# Altium schematic sheet Transcurrent.SchDoc
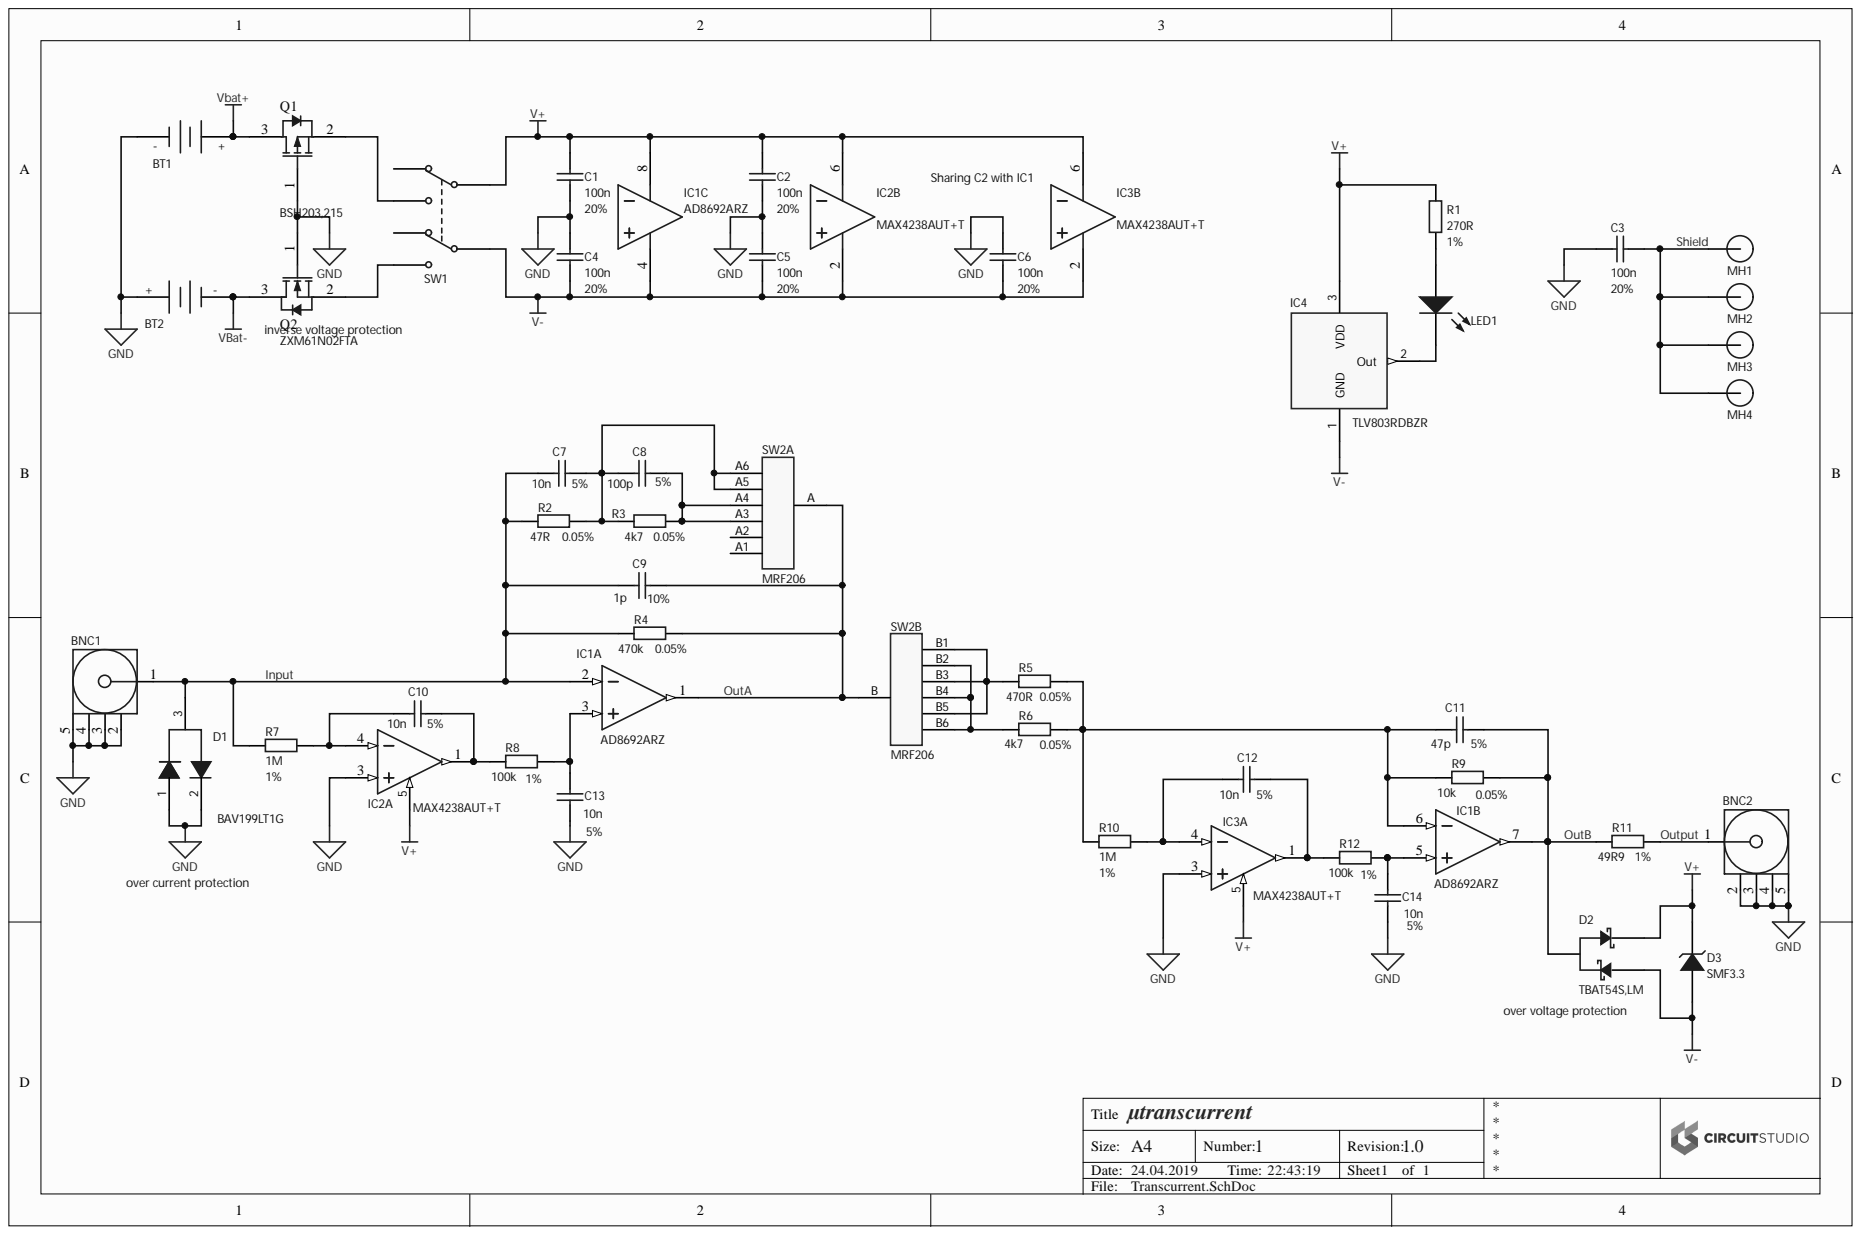
[diagram p0: Transcurrent.SchDoc]

## Parts
BNC1  BNC female angled  [BNC 90°]  (value not drawn)
BNC2  BNC female angled  [BNC 90°]  (value not drawn)
BT1  Battery  [Keystone1020]  (value not drawn)
BT2  Battery  [Keystone1020]  (value not drawn)
C1  value 100n  Tolerance: 20%  [C0805]  (hidden comment: Cap 100n 0805)
C2  value 100n  Tolerance: 20%  [C0805]  (hidden comment: Cap 100n 0805)
C3  value 100n  Tolerance: 20%  [C0805]  (hidden comment: Cap 100n 0805)
C4  value 100n  Tolerance: 20%  [C0805]  (hidden comment: Cap 100n 0805)
C5  value 100n  Tolerance: 20%  [C0805]  (hidden comment: Cap 100n 0805)
C6  value 100n  Tolerance: 20%  [C0805]  (hidden comment: Cap 100n 0805)
C7  value 10n  Tolerance: 5%  [C0805]  (hidden comment: C0805C103J5GACTU)
C8  value 100p  Tolerance: 5%  [C0805]  (hidden comment: CL21C101JC61PNC)
C9  value 1p  Tolerance: 10%  [C0805]  (hidden comment: CC0805BRNPO9BN1R0)
C10  value 10n  Tolerance: 5%  [C0805]  (hidden comment: C0805C103J5GACTU)
C11  value 47p  Tolerance: 5%  [C0805]  (hidden comment: CC0805JRNPO9BN470)
C12  value 10n  Tolerance: 5%  [C0805]  (hidden comment: C0805C103J5GACTU)
C13  value 10n  Tolerance: 5%  [C0805]  (hidden comment: C0805C103J5GACTU)
C14  value 10n  Tolerance: 5%  [C0805]  (hidden comment: C0805C103J5GACTU)
D1  BAV199LT1G  [SOT23-3]
D2  TBAT54S  MPN: TBAT54S,LM  [SOT23-3]
D3  D Zener  [SOD 123-FL]  (value not drawn)
IC1  AD8692ARZ  [SO8_N]  (parts A,B,C)
IC2  MAX4238AUT+T  [SOT23-6]  (parts A,B)
IC3  MAX4238AUT+T  [SOT23-6]  (parts A,B)
IC4  TLV803RDBZR  [SOT23-3]
LED1  LED  [LED_1206_revers]
MH1  Mounting Hole M3.5  [M3.5 DIN84]  (value not drawn)  (no BOM)
MH2  Mounting Hole M3.5  [M3.5 DIN84]  (value not drawn)  (no BOM)
MH3  Mounting Hole M3.5  [M3.5 DIN84]  (value not drawn)  (no BOM)
MH4  Mounting Hole M3.5  [M3.5 DIN84]  (value not drawn)  (no BOM)
Q1  BSH203,215  [SOT23-3]
Q2  ZXM61N02FTA  [SOT23-3]
R1  value 270R  Tolerance: 1%  [R0805]  (hidden comment: Res 270R 0805)
R2  value 47R  Tolerance: 0.05%  [R0805]  (hidden comment: RG20N47WCT-ND)
R3  value 4k7  Tolerance: 0.05%  [R0805]  (hidden comment: Res2)
R4  value 470k  Tolerance: 0.05%  [R0805]  (hidden comment: RG2012N-474-W-T1)
R5  value 470R  Tolerance: 0.05%  [R0805]  (hidden comment: RG2012N-471-W-T1)
R6  value 4k7  Tolerance: 0.05%  [R0805]  (hidden comment: Res2)
R7  value 1M  Tolerance: 1%  [R0805]  (hidden comment: Res 1M 0805)
R8  value 100k  Tolerance: 1%  [R0805]  (hidden comment: Res 100k 0805)
R9  value 10k  Tolerance: 0.05%  [R0805]  (hidden comment: RG20N10.0KWCT-ND)
R10  value 1M  Tolerance: 1%  [R0805]  (hidden comment: Res 1M 0805)
R11  value 49R9  Tolerance: 1%  [R0805]  (hidden comment: Res 49R9 0805)
R12  value 100k  [R0805]  (hidden comment: Res 100k 0805)
SW1  MMP 221  [MMP 221]  (value not drawn)
SW2  MRF206  [MKF206]  (parts A,B)

## Nets
GND: BNC1.2 BNC1.3 BNC1.4 BNC1.5 BNC2.2 BNC2.3 BNC2.4 BNC2.5 BT1.1 BT2.2 C1.1 C2.1 C3.1 C4.2 C5.2 C6.2 C13.1 C14.1 D1.1 D1.2 IC2.3 IC3.3 Q1.1 Q2.1
V+: C1.2 C2.2 D2.2 D3.2 IC1.8 IC2.5 IC2.6 IC3.5 IC3.6 IC4.3 R1.2 SW1.2
V-: C4.1 C5.1 C6.1 D2.1 D3.1 IC1.4 IC2.2 IC3.2 IC4.1 SW1.5
VBAT+: BT1.2 Q1.3
VBAT-: BT2.1 Q2.3
INPUT: BNC1.1 C7.1 C9.1 D1.3 IC1.2 R2.1 R4.1 R7.1
OUTA: C9.2 IC1.1 R4.2 SW2.A SW2.B
OUTB: C11.2 D2.3 IC1.7 R9.2 R11.1
OUTPUT: BNC2.1 R11.2
SHIELD: C3.2 MH1.0 MH2.0 MH3.0 MH4.0
NetC7_2: C7.2 C8.1 R2.2 R3.1 SW2.A5 SW2.A6
NetC8_2: C8.2 R3.2 SW2.A3 SW2.A4
NetC10_1: C10.1 IC2.4 R7.2
NetC10_2: C10.2 IC2.1 R8.1
NetC11_1: C11.1 IC1.6 R5.2 R6.2 R9.1 R10.1
NetC12_1: C12.1 IC3.4 R10.2
NetC12_2: C12.2 IC3.1 R12.1
NetC13_2: C13.2 IC1.3 R8.2
NetC14_2: C14.2 IC1.5 R12.2
NetIC4_2: IC4.2 LED1.2
NetLED1_1: LED1.1 R1.1
NetQ1_2: Q1.2 SW1.3
NetQ2_2: Q2.2 SW1.6
NetR5_1: R5.1 SW2.B1 SW2.B3 SW2.B5
NetR6_1: R6.1 SW2.B2 SW2.B4 SW2.B6

Not connected: SW1 1 4; SW2 A1 A2

## Library data of the parts
BNC1 BNC2 = J01001A1948: Connector, BNC THT 50R angled; Manufacturer: Telegärtner; Component Kind: Standard; MPN: J01001A1948; Supplier1: Völkner; Supplier1 Partnumber: V076191; Library Name: Transcurrent.SchLib
BT1 BT2 = Keystone1020: BATTERY HOLDER AAA SMD TAB; Manufacturer: Keystone Electronics; MPN: 1020; Component Kind: Standard; Supplier1: Digikey; Supplier1 Partnumber: 36-1020-ND; Library Name: Transcurrent.SchLib
C1-C6 = C0805_100n: Capacitor 100n 20% 0805; Manufacturer: Any; Component Kind: Standard; Library Name: RCL.SchLib; Supplier1: Any
C7 C10 C12-C14 = C0805C103J5GACTU: CAP CER 10nF 50V C0G/NP0 0805; Manufacturer: Kemet; Component Kind: Standard; MPN: C0805C103J5GACTU; Supplier1: Digikey; Supplier1 Partnumber: 399-7992-1-ND; Library Name: Transcurrent.SchLib
C8 = CL21C101JC61PNC: CAP CER 100PF 100V C0G/NP0 0805; Manufacturer: Samsung; Component Kind: Standard; MPN: CL21C101JC61PNC; Supplier1: Digikey; Supplier1 Partnumber: 1276-2569-1-ND; Library Name: Transcurrent.SchLib
C9 = CC0805BRNPO9BN1R0: CAP CER 1PF 50V NPO 0805; Manufacturer: Yageo; Component Kind: Standard; MPN: CC0805BRNPO9BN1R0; Supplier1: Digikey; Supplier1 Partnumber: 311-4124-1-ND; Library Name: Transcurrent.SchLib
C11 = CC0805JRNPO9BN470: CAP CER 47PF 50V C0G/NPO 0805; Manufacturer: Yageo; Component Kind: Standard; MPN: CC0805JRNPO9BN470; Supplier1: Digikey; Supplier1 Partnumber: 311-1107-1-ND; Library Name: Transcurrent.SchLib
D1 = BAV199LT1G: DIODE ARRAY GP 70V 215MA SOT23-3; Manufacturer: ON Semiconductor; Component Kind: Standard; MPN: BAV199LT1G; Supplier1: Digikey; Supplier1 Partnumber: BAV199LT1GOSCT-ND; Library Name: Transcurrent.SchLib
D2 = TBAT54S: DIODE SCHOTTKY 30V 140MA SOT23; Manufacturer: Toshiba; Component Kind: Standard; Supplier1: Digikey; Supplier1 Partnumber: TBAT54SLMCT-ND; Library Name: Transcurrent.SchLib
D3 = SMF3.3: TVS DIODE 3.3V 6.8V SOD123FL; Manufacturer: Littelfuse Inc.; Component Kind: Standard; MPN: SMF3.3; Supplier1: Digikey; Supplier1 Partnumber: F7701CT-ND; Library Name: Transcurrent.SchLib
IC1 = AD8692ARZ: IC OPAMP GP 10MHZ RRO 8SOIC; Manufacturer: Analog Devices; Component Kind: Standard; MPN: AD8692ARZ; Supplier1: Digikey; Supplier1 Partnumber: AD8692ARZ-REELCT-ND; Library Name: Transcurrent.SchLib
IC2 IC3 = MAX4238AUT+T: OpAmp, low noise, auto zero; Manufacturer: Maxim Integrated; Component Kind: Standard; MPN: MAX4238AUT+T; Supplier1: Digikey; Supplier1 Partnumber: MAX4238AUT+TCT-ND; Library Name: Transcurrent.SchLib
IC4 = TLV803RDBZR: IC VOLT SUPERVISOR 2.64V SOT23-3; Manufacturer: Texas Instruments; Component Kind: Standard; MPN: TLV803RDBZR; Supplier1: Digikey; Supplier1 Partnumber: 296-29195-1-ND; Library Name: Transcurrent.SchLib
LED1 = 156120RS75300: LED rot 1206 revers; Manufacturer: Würth Elektronik; Color: red; Component Kind: Standard; MPN: 156120RS75300; Supplier1: Digikey; Supplier1 Partnumber: 732-5031-1-ND; Library Name: Transcurrent.SchLib
Q1 = BSH203,215: MOSFET P-CH 30V 470MA SOT23; Manufacturer: Nexperia; Component Kind: Standard; MPN: BSH203,215; Supplier1: Digikey; Supplier1 Partnumber: 1727-6216-1-ND; Library Name: Transcurrent.SchLib
Q2 = ZXM61N02FTA: MOSFET N-CH 20V 1.7A SOT23-3; Manufacturer: Diodes Inc.; Component Kind: Standard; MPN: ZXM61N02FTA; Supplier1: Digikey; Supplier1 Partnumber: ZXM61N02FCT-ND; Library Name: Transcurrent.SchLib
R1 = R0805_270R: Resistor 270R 1% 0805; Manufacturer: Any; Component Kind: Standard; Library Name: RCL.SchLib; Supplier1: Any
R2 = RG2012N-470-W-T1: RES SMD 47 OHM 0.05% 1/8W 0805; Manufacturer: Susumu; Component Kind: Standard; MPN: RG2012N-470-W-T1; Supplier1: Digikey; Supplier1 Partnumber: RG20N47WCT-ND; Library Name: Transcurrent.SchLib
R3 R6 = ERA-6ARW472V: RES SMD 4k7 OHM 0.05% 1/8W 0805; Manufacturer: Panasonic; Component Kind: Standard; MPN: ERA-6ARW472V; Supplier1: Digikey; Supplier1 Partnumber: P4.7KBSCT-ND; Library Name: Transcurrent.SchLib
R4 = RG2012N-474-W-T1: RES SMD 470K OHM 0.05% 1/8W 0805; Manufacturer: Susumu; Component Kind: Standard; MPN: RG2012N-474-W-T1; Supplier1: Digikey; Supplier1 Partnumber: RG20N470KWCT-ND; Library Name: Transcurrent.SchLib
R5 = RG2012N-471-W-T1: RES SMD 470 OHM 0.05% 1/8W 0805; Manufacturer: Susumu; Component Kind: Standard; MPN: RG2012N-471-W-T1; Supplier1: Digikey; Supplier1 Partnumber: RG20N470WCT-ND; Library Name: Transcurrent.SchLib
R7 R10 = R0805_1M: Resistor 1M 1% 0805; Manufacturer: Any; Component Kind: Standard; Library Name: RCL.SchLib; Supplier1: Any
R8 R12 = R0805_100k: Resistor 100k 1% 0805; Manufacturer: Any; Component Kind: Standard; Library Name: RCL.SchLib; Supplier1: Any
  R12: Tolerance: 1%
R9 = RG2012N-103-W-T1: RES SMD 10K OHM 0.05% 1/8W 0805; Manufacturer: Susumu; Component Kind: Standard; MPN: RG2012N-103-W-T1; Supplier1: Digikey; Supplier1 Partnumber: RG20N10.0KWCT-ND; Library Name: Transcurrent.SchLib
R11 = R0805_49R9: Resistor 49R9 1% 0805; Manufacturer: Any; Component Kind: Standard; Library Name: MyLibrary.IntLib; Supplier1: Any
SW1 = MMP 221: Switch, DPDT THT mini; Manufacturer: Knitterswitch; MPN: 221; Component Kind: Standard; Supplier1: Völkner; Supplier1 Partnumber: D73482; Library Name: Transcurrent.SchLib
SW2 = MRF206: DP6T Rotary Switch; Manufacturer: NKK Switches; Component Kind: Standard; MPN: MRF206; Supplier1: Digikey; Supplier1 Partnumber: 360-2371-ND; Supplier2: Voelkner; Supplier2 Partnumber: D70253; Library Name: Transcurrent.SchLib
MH1-MH4 = Mounting Hole M3.5: Library Name: Transcurrent.SchLib
